# Altium schematic sheet Connectors.SchDoc(Connectors)
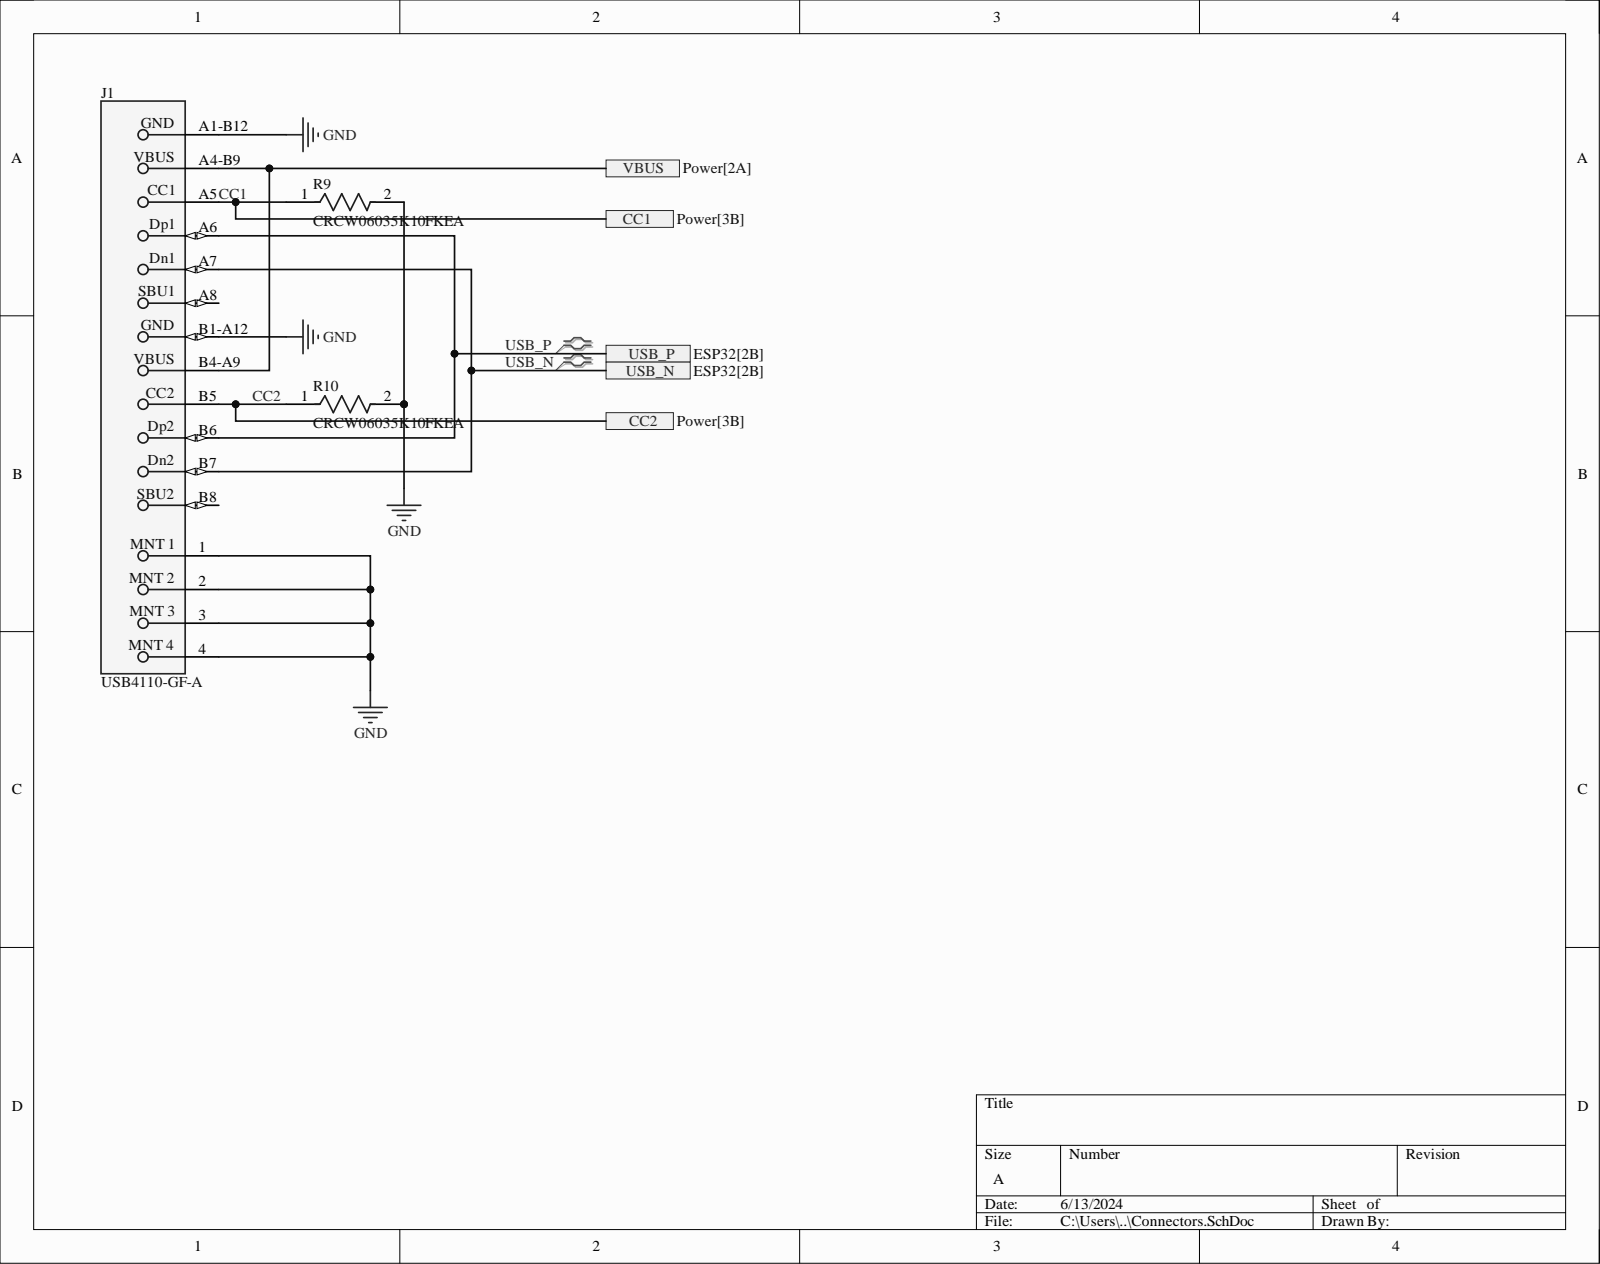
[diagram p0: Connectors.SchDoc]

## Parts
J1  USB4110-GF-A  [FP-USB4110-GF-A-MFG]
R9  CRCW06035K10FKEA  [FP-CRCW0603-e3-IPC_C]
R10  CRCW06035K10FKEA  [FP-CRCW0603-e3-IPC_C]

## Ports
CC1, CC2, USB_N, USB_P, VBUS

## Nets
GND: J1.1 J1.2 J1.3 J1.4 J1.A1-B12 J1.B1-A12 R9.2 R10.2
CC1: J1.A5 R9.1 ; port CC1
CC2: J1.B5 R10.1 ; port CC2
USB_N: J1.A7 J1.B7 ; port USB_N
USB_P: J1.A6 J1.B6 ; port USB_P
VBUS: J1.A4-B9 J1.B4-A9 ; port VBUS

Not connected: J1 A8 B8

## Library data of the parts
J1 = CMP-245163-000002-1: CONN USB 2.0 TYPE-C R/A SMT; Manufacturer: GCT; Conn Orientation: Right Angle; Current Rating: 3/4.25/1.25/0.25A; Is Female: yes; Number Of Contacts: 16; Shielding: true; Standoff Height: 0.1mm; Usb Standard: USB 2.0; Voltage Rating: 20V; Automotive: No; Category: Conn; Component Kind: Standard; ComponentLink2Description: Datasheet; ComponentLink2URL: https://gct.co/files/drawings/usb4110.pdf; Contact Material: Gold,Nickel; Device Class L1: Connectors; Device Class L2: USB Connectors; Device Class L3: unset; Digikey Description: CONN USB 2.0 TYPE-C R/A SMT; Height: 3.46mm; Lead Free: Yes; Library Name: Altium Content Vault; Manufacturer Part Number: USB4110-GF-A; Octopart: https://octopart.com/usb4110-gf-a-gct-103941985; Package: 0; RoHS: TRUE; Temperature: -40°C to +85°C; Temperature Range High: +85°C; Temperature Range Low: -40°C
R9 R10 = CMP-1012-00590-3: RES Thick Film, 5.1kΩ, 1%, 0.1W, 100ppm/°C, 0603; Power Rating: 100mW; Resistance: 5.1kR; Voltage Rating: 75V; Case Code (Imperial): 0603; Case Code (Metric): 1608; Case/Package: 0603; Component Kind: Standard; Composition: Thick Film; Contact Plating: Tin; Depth: 0.85mm; Height: 0.45mm; Lead Free: Lead Free; Length: 1.55mm; Library Name: Altium Content Vault; Max Operating Temperature: 155°C; Military Standard: Not; Min Operating Temperature: -55°C; Mount: Surface Mount; Package Quantity: 5000; Packaging: Tape and Reel; Radiation Hardening: No; Temperature Coefficient: 100ppm/°C; Termination: SMD/SMT; Tolerance: 1%; Voltage Rating (DC): 75V; Weight: 7.1E-05oz; Width: 0.85mm
